# Altium schematic sheet frm-mw-diode-detector.SchDoc
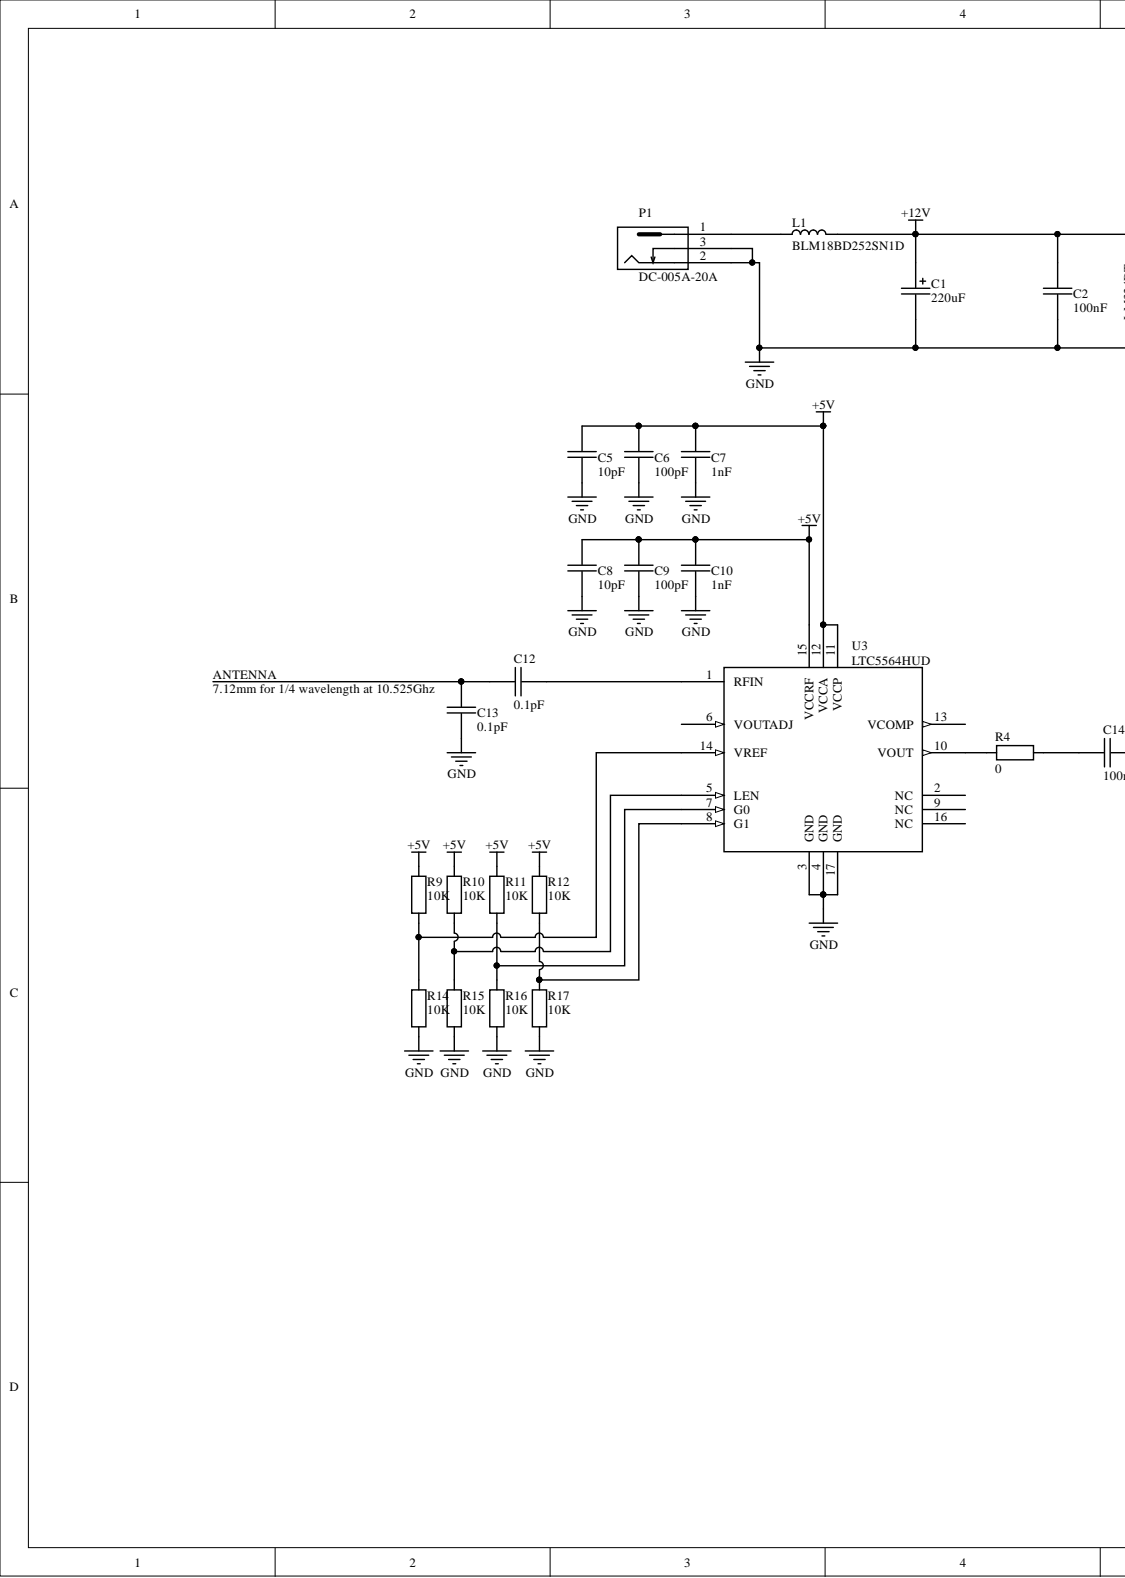
[diagram p0: frm-mw-diode-detector.SchDoc, left]
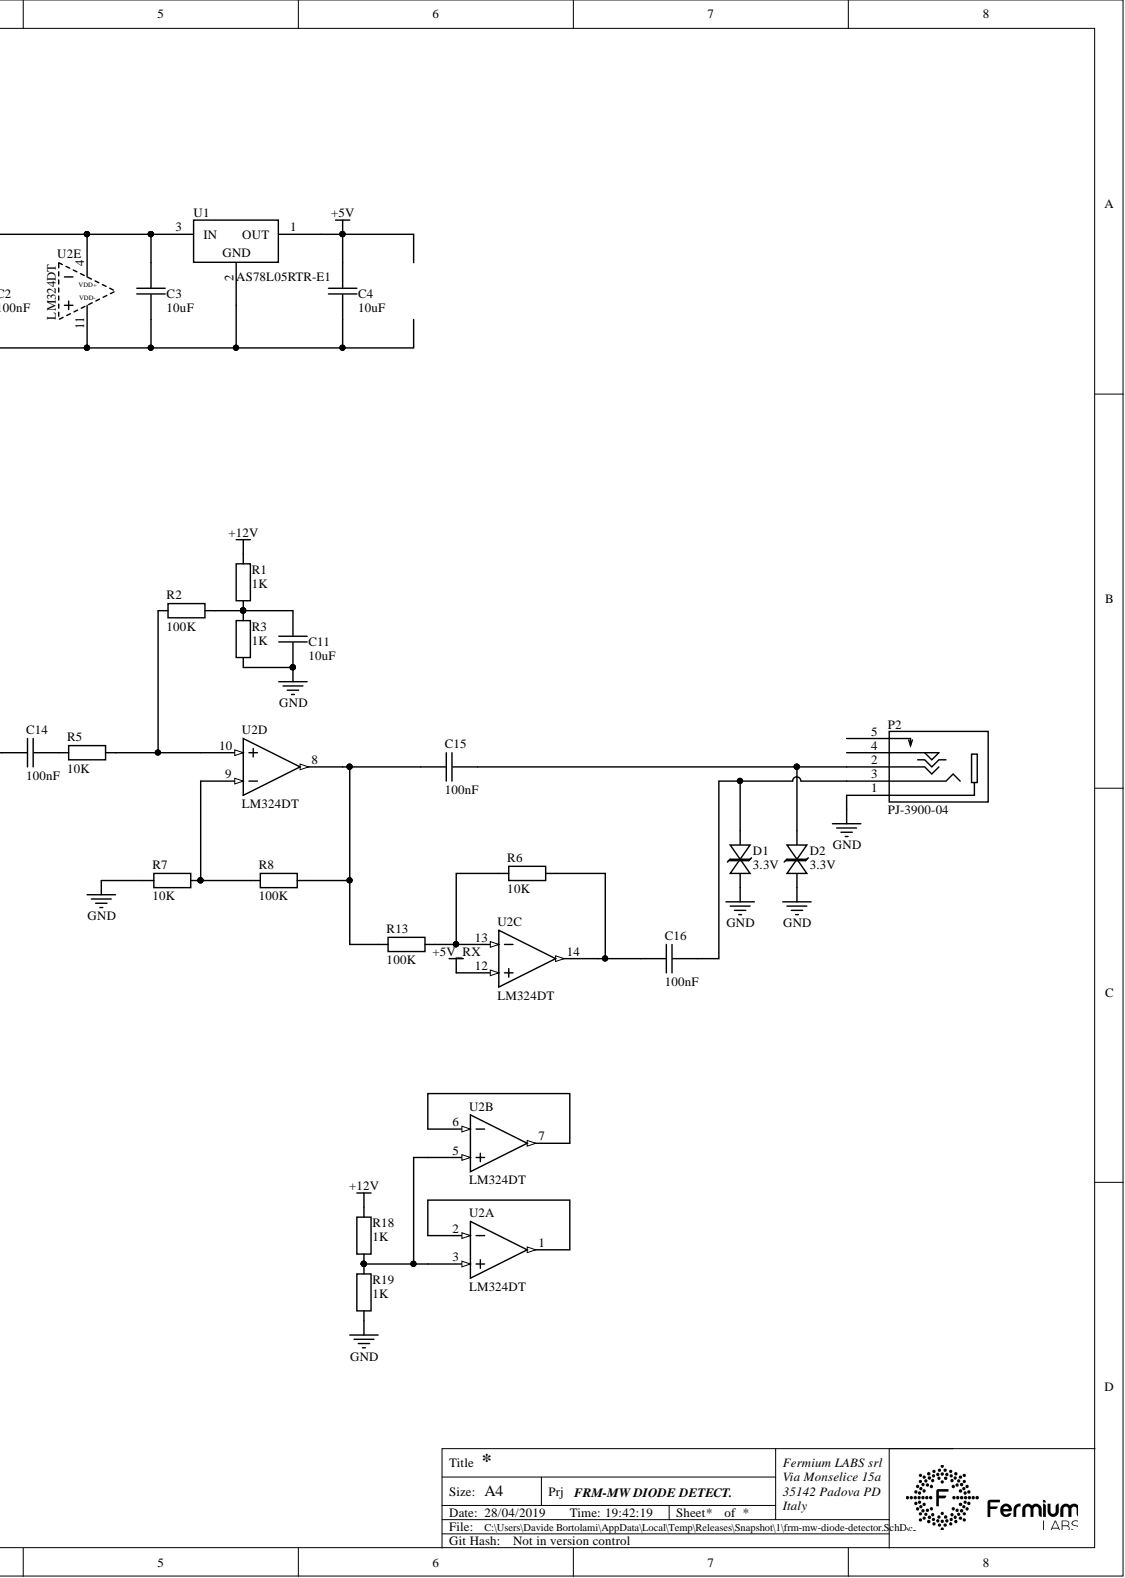
[diagram p1: frm-mw-diode-detector.SchDoc, right]

## Parts
C1  220uF  [3924B366-8672-45E9-B0BE-62DACC24E783]
C2  100nF  [CAP 0603_1608]
C3  10uF  [CAP 0805_2012]
C4  10uF  [CAP 0805_2012]
C5  10pF  [CAP 0603_1608]
C6  100pF  [CAP 0603_1608]
C7  1nF  [CAP 0603_1608]
C8  10pF  [CAP 0603_1608]
C9  100pF  [CAP 0603_1608]
C10  1nF  [CAP 0603_1608]
C11  10uF  [CAP 0805_2012]
C12  0.1pF  [CAP 0402_1005 RF]
C13  0.1pF  [CAP 0402_1005 RF]
C14  100nF  [CAP 0603_1608]
C15  100nF  [CAP 0603_1608]
C16  100nF  [CAP 0603_1608]
D1  3.3V  [SOD523F]
D2  3.3V  [SOD523F]
L1  BLM18BD252SN1D  [FER 0603_1608]
P1  DC-005A-20A  [F0972D8A-C5C0-4A84-836D-A9666EE65C2D]
P2  PJ-3900-04  [7EF2B92C-0FC9-4C85-B662-5CEC65BFCCC4]
R1  1K  [RES 0603_1608]
R2  100K  [RES 0603_1608]
R3  1K  [RES 0603_1608]
R4  0  [RES 0603_1608]
R5  10K  [RES 0603_1608]
R6  10K  [RES 0603_1608]
R7  10K  [RES 0603_1608]
R8  100K  [RES 0603_1608]
R9  10K  [RES 0603_1608]
R10  10K  [RES 0603_1608]
R11  10K  [RES 0603_1608]
R12  10K  [RES 0603_1608]
R13  100K  [RES 0603_1608]
R14  10K  [RES 0603_1608]
R15  10K  [RES 0603_1608]
R16  10K  [RES 0603_1608]
R17  10K  [RES 0603_1608]
R18  1K  [RES 0603_1608]
R19  1K  [RES 0603_1608]
U1  AS78L05RTR-E1  [E733EFB9-FE91-4AE9-9197-29251971C3DC]
U2  LM324DT  [34355DA5-B1DA-47C2-A88A-ECF82120A4F7]  (parts A,B,C,D,E)
U3  LTC5564HUD  [1C9CA8C2-7F7E-4E2B-A488-6DD37E6BADEA]

## Nets
+5V: C4.2 C5.1 C6.1 C7.1 C8.1 C9.1 C10.1 R9.2 R10.2 R11.2 R12.2 U1.1 U3.11(VCCP) U3.12(VCCA) U3.15(VCCRF)
+5V_RX: U2.12
+12V: C1.1 C2.2 C3.2 L1.2 R1.2 R18.2 U1.3 U2.4
GND: C1.2 C2.1 C3.1 C4.1 C5.2 C6.2 C7.2 C8.2 C9.2 C10.2 C11.2 C13.1 D1.2 D2.2 P1.2 P1.3 P2.1 R3.1 R7.2 R14.1 R15.1 R16.1 R17.1 R19.1 U1.2 U2.11 U3.3(GND) U3.4(GND) U3.17(GND)
ANTENNA: C12.1 C13.2
NetC11_1: C11.1 R1.1 R2.2 R3.2
NetC12_2: C12.2 U3.1(RFIN)
NetC14_1: C14.1 R4.1
NetC14_2: C14.2 R5.2
NetC15_1: C15.1 R8.1 R13.2 U2.8
NetC15_2: C15.2 D2.1 P2.2
NetC16_1: C16.1 R6.1 U2.14
NetC16_2: C16.2 D1.1 P2.3
NetL1_1: L1.1 P1.1
NetR2_1: R2.1 R5.1 U2.10
NetR4_2: R4.2 U3.10(VOUT)
NetR6_2: R6.2 R13.1 U2.13
NetR7_1: R7.1 R8.2 U2.9
NetR9_1: R9.1 R14.2 U3.14(VREF)
NetR10_1: R10.1 R15.2 U3.5(LEN)
NetR11_1: R11.1 R16.2 U3.7(G0)
NetR12_1: R12.1 R17.2 U3.8(G1)
NetR18_1: R18.1 R19.2 U2.3 U2.5
NetU2_1: U2.1 U2.2
NetU2_6: U2.6 U2.7

Not connected: P2 4 5; U3 2(NC) 6(VOUTADJ) 9(NC) 13(VCOMP) 16(NC)

## Library data of the parts
C1 = 1a28df19-6d3e-4a71-836c-f22920f8e8ab: CAP  THT ELEC 220uF 25V 20%; Manufacturer 1: Rubycon; Manufacturer Part Number 1: 25PK220MEFC-6.3*11; Database Table Name: public.fermiumlabs_all_pivoted; id: 14782; Component Kind: Standard; ComponentLink1Description: Datasheet; ComponentLink1URL: http://localhost:8080/static/files/Rubycon-220uF-25V_C109337_12019-03-10_214953.757848.pdf?Rubycon-220uF-25V_C109337 (1).pdf; Part Number: 1a28df19-6d3e-4a71-836c-f22920f8e8ab; Value: 220uF; Library Name: frmdblib.DbLib
C2 C14-C16 = 02841f84-ac15-41b5-9e17-915f8f067828: CAP SMD MLCC 100nF 25V 0603 X7R; Manufacturer 1: Samsung Electro-Mechanics; Manufacturer Part Number 1: CL10B104KA8NNNC; Database Table Name: public.fermiumlabs_all_pivoted; id: 14784; Component Kind: Standard; ComponentLink1Description: Datasheet; ComponentLink1URL: http://localhost:8080/static/files/Samsung-Electro-Mechanics-CL10B104KA8NNNC_C15902019-03-10_215548.826887.pdf?Samsung-Electro-Mechanics-CL10B104KA8NNNC_C1590.pdf; Part Number: 02841f84-ac15-41b5-9e17-915f8f067828; Value: 100nF; Library Name: frmdblib.DbLib
C3 C4 C11 = 1ffbe5a9-0884-4740-bdad-20a6a98400e6: CAP SMD MLCC 10uF 25V 0805 X5R; Manufacturer 1: Samsung Electro-Mechanics; Manufacturer Part Number 1: CL21A106KAYNNNE; Database Table Name: public.fermiumlabs_all_pivoted; id: 14779; Component Kind: Standard; ComponentLink1Description: Datasheet; ComponentLink1URL: http://localhost:8080/static/files/Samsung-Electro-Mechanics-CL21A106KAYNNNE_C158502019-03-10_213827.610739.pdf?Samsung-Electro-Mechanics-CL21A106KAYNNNE_C15850.pdf; Part Number: 1ffbe5a9-0884-4740-bdad-20a6a98400e6; Value: 10uF; Library Name: frmdblib.DbLib
C5 C8 = b659b677-71ac-4e84-9ac8-47a475f18d31: CAP SMD MLCC 10pF 50V 0603 C0G/NP0; Manufacturer 1: Yageo; Manufacturer Part Number 1: CC0603GRNPO9BN100; Database Table Name: public.fermiumlabs_all_pivoted; id: 14805; Component Kind: Standard; Part Number: b659b677-71ac-4e84-9ac8-47a475f18d31; Value: 10pF; Library Name: frmdblib.DbLib
C6 C9 = ccf679fd-1b09-4431-be81-04c3ce295510: CAP SMD MLCC 100pF 100V 0603 C0G/NP0; Manufacturer 1: Yageo; Manufacturer Part Number 1: CC0603JRNPO0BN101; Database Table Name: public.fermiumlabs_all_pivoted; id: 14804; Component Kind: Standard; Part Number: ccf679fd-1b09-4431-be81-04c3ce295510; Value: 100pF; Library Name: frmdblib.DbLib
C7 C10 = 0eca2548-3630-4fea-a3d5-94248c1cae74: CAP SMD MLCC 1nF 50V 0603 X7R; Manufacturer 1: Samsung Electro-Mechanics; Manufacturer Part Number 1: CL10B102KB8NNNC; Database Table Name: public.fermiumlabs_all_pivoted; id: 14785; Component Kind: Standard; ComponentLink1Description: Datasheet; ComponentLink1URL: http://localhost:8080/static/files/Samsung-Electro-Mechanics-CL10B102KB8NNNC_C1588_12019-03-10_215732.131818.pdf?Samsung-Electro-Mechanics-CL10B102KB8NNNC_C1588 (1).pdf; Part Number: 0eca2548-3630-4fea-a3d5-94248c1cae74; Value: 1nF; Library Name: frmdblib.DbLib
C12 C13 = 26a1b8a5-3146-4e38-b8a1-20cf4d8b229c: CAP SMD MLCC 0.1pF 50V 0402 HIGH-Q; Manufacturer 1: Murata; Manufacturer Part Number 1: GJM1555C1HR10BB01D; Database Table Name: public.fermiumlabs_all_pivoted; id: 14808; Component Kind: Standard; Part Number: 26a1b8a5-3146-4e38-b8a1-20cf4d8b229c; Value: 0.1pF; Library Name: frmdblib.DbLib
D1 D2 = d6266fd0-7428-4fb9-a062-338cdce926fd: DIODE SMD TVS 3.3V BIDIRECTIONAL SOD-523; Manufacturer 1: Born Semiconductor; Manufacturer Part Number 1: PESD3V3S1BA-N; Database Table Name: public.fermiumlabs_all_pivoted; id: 14798; Component Kind: Standard; ComponentLink1Description: Datasheet; ComponentLink1URL: http://localhost:8080/static/files/Bourne-Semicon-Shenzhen-PESD3V3S1BA-N_C3160372019-04-07_002431.794112.pdf?Bourne-Semicon-Shenzhen-PESD3V3S1BA-N_C316037.pdf; Part Number: d6266fd0-7428-4fb9-a062-338cdce926fd; Value: 3.3V; Library Name: frmdblib.DbLib
L1 = c9e30ae8-9d5b-4135-a079-b1147da846d8: FER SMD CHIP 0603 400mA 1k@100MHz 500mOhm; Manufacturer 1: Murata; Database Table Name: public.fermiumlabs_all_pivoted; id: 14786; Component Kind: Standard; ComponentLink1Description: Datasheet; ComponentLink1URL: http://localhost:8080/static/files/Murata-Electronics-BLM18AG102SN1D_C858242019-03-10_220021.186607.pdf?Murata-Electronics-BLM18AG102SN1D_C85824.pdf; Manufacturer Part Number 1: BLM18BD252SN1D; Part Number: c9e30ae8-9d5b-4135-a079-b1147da846d8; Library Name: frmdblib.DbLib
P1 = b53db51a-1725-412b-9039-fa7a45c7c438: CONN THT DC JACK 0.5A 30VDC 5Kcycles; Manufacturer 1: HRO Electronics; Database Table Name: public.fermiumlabs_all_pivoted; id: 14780; Component Kind: Standard; ComponentLink1Description: Datasheet; ComponentLink1URL: http://localhost:8080/static/files/Korean-Hroparts-Elec-DC-005A-20A_C191049_22019-03-10_214231.486078.pdf?Korean-Hroparts-Elec-DC-005A-20A_C191049 (2).pdf; Manufacturer Part Number 1: DC-005A-20A; Part Number: b53db51a-1725-412b-9039-fa7a45c7c438; Library Name: frmdblib.DbLib
P2 = 80507a24-5d7c-4cf5-953e-f54832d54564: CONN THT 3.5mm AUDIO STEREO AUX SWITCH; Manufacturer 1: HRO Electronics; Database Table Name: public.fermiumlabs_all_pivoted; id: 14793; Component Kind: Standard; ComponentLink1Description: Datasheet; ComponentLink1URL: http://localhost:8080/static/files/Korean-Hroparts-Elec-PJ-3900-04_C1518392019-04-10_231144.687617.pdf?Korean-Hroparts-Elec-PJ-3900-04_C151839.pdf; Manufacturer Part Number 1: PJ-3900-04; Part Number: 80507a24-5d7c-4cf5-953e-f54832d54564; Library Name: frmdblib.DbLib
R1 R3 R18 R19 = a678220f-9e9d-4863-a833-9993504f723c: RES SMD 1K OHM 1% 1/10W 0603; Manufacturer 1: Yageo; Manufacturer Part Number 1: RC0603FR-071KL; Database Table Name: public.fermiumlabs_all_pivoted; id: 14794; Component Kind: Standard; Part Number: a678220f-9e9d-4863-a833-9993504f723c; Value: 1K; Library Name: frmdblib.DbLib
R2 R8 R13 = 76af10b3-9d85-4b5c-a2d6-26cc74f432f7: RES SMD 100K OHM 1% 1/10W 0603; Manufacturer 1: Yageo; Manufacturer Part Number 1: RC0603FR-07100KL; Database Table Name: public.fermiumlabs_all_pivoted; id: 12746; Component Kind: Standard; Part Number: 76af10b3-9d85-4b5c-a2d6-26cc74f432f7; Value: 100K; Library Name: frmdblib.DbLib
R4 = 6ae227f9-847f-4766-8522-e11a83531204: RES SMD 0 OHM 5% 1/10W 0603; Manufacturer 1: Yageo; Manufacturer Part Number 1: RC0603JR-070RL; Database Table Name: public.fermiumlabs_all_pivoted; id: 11973; Component Kind: Standard; Part Number: 6ae227f9-847f-4766-8522-e11a83531204; Value: 0; Library Name: frmdblib.DbLib
R5-R7 R9-R12 R14-R17 = 93242766-ba1d-462d-b58f-ce1dfc4129c8: RES SMD 10K OHM 1% 1/10W 0603; Manufacturer 1: Yageo; Manufacturer Part Number 1: RC0603FR-0710KL; Database Table Name: public.fermiumlabs_all_pivoted; id: 12101; Component Kind: Standard; Part Number: 93242766-ba1d-462d-b58f-ce1dfc4129c8; Value: 10K; Library Name: frmdblib.DbLib
U1 = fd64863e-487f-4688-b264-696c0ba5456b: IC SMD LINEAR VOLTAGE REGULATOR 5V 100mA SOT-89; Manufacturer 1: Diodes Inc.; Database Table Name: public.fermiumlabs_all_pivoted; id: 14783; Component Kind: Standard; ComponentLink1Description: Datasheet; ComponentLink1URL: http://localhost:8080/static/files/Diodes-Incorporated-AS78L05RTR-E1_C90471_12019-03-10_215252.941962.pdf?Diodes-Incorporated-AS78L05RTR-E1_C90471 (1).pdf; ComponentLink2Description: Datasheet; ComponentLink2URL: http://localhost:8080/static/files/KIA-Semicon-Tech-KIA78L05T_C90763_12019-03-10_215415.523371.pdf?KIA-Semicon-Tech-KIA78L05T_C90763 (1).pdf; Manufacturer 2: KIA Semicon Tech; Manufacturer Part Number 1: AS78L05RTR-E1; Manufacturer Part Number 2: KIA78L05T; Part Number: fd64863e-487f-4688-b264-696c0ba5456b; Library Name: frmdblib.DbLib
U2 = 21a85167-c328-42f7-a52e-365842c6dad3: IC SMD OPAMP LM324DT SO-14; Manufacturer 1: ST Microelectronics; Database Table Name: public.fermiumlabs_all_pivoted; id: 14792; Component Kind: Standard; ComponentLink1Description: Datasheet; ComponentLink1URL: http://localhost:8080/static/files/STMicroelectronics-LM324DT_C710352019-04-06_191500.254110.pdf?STMicroelectronics-LM324DT_C71035.pdf; Manufacturer Part Number 1: LM324DT; Part Number: 21a85167-c328-42f7-a52e-365842c6dad3; Library Name: frmdblib.DbLib
U3 = 45536c7f-5716-49d5-adce-f87923efcc45: IC SMD RF POWER DETECTOR 15GHz LTC5564 QFN-16; Manufacturer 1: Analog Devices / Linear Technology; Database Table Name: public.fermiumlabs_all_pivoted; id: 14803; Component Kind: Standard; ComponentLink1Description: Datasheet; ComponentLink1URL: http://localhost:8080/static/files/5564fc2019-04-28_163050.562654.pdf?5564fc.pdf; Manufacturer 2: Analog Devices / Linear Technology; Manufacturer Part Number 1: LTC5564HUD; Manufacturer Part Number 2: LTC5564IUD; Part Number: 45536c7f-5716-49d5-adce-f87923efcc45; Library Name: frmdblib.DbLib
